# Altium schematic sheet p08_Camera.SchDoc
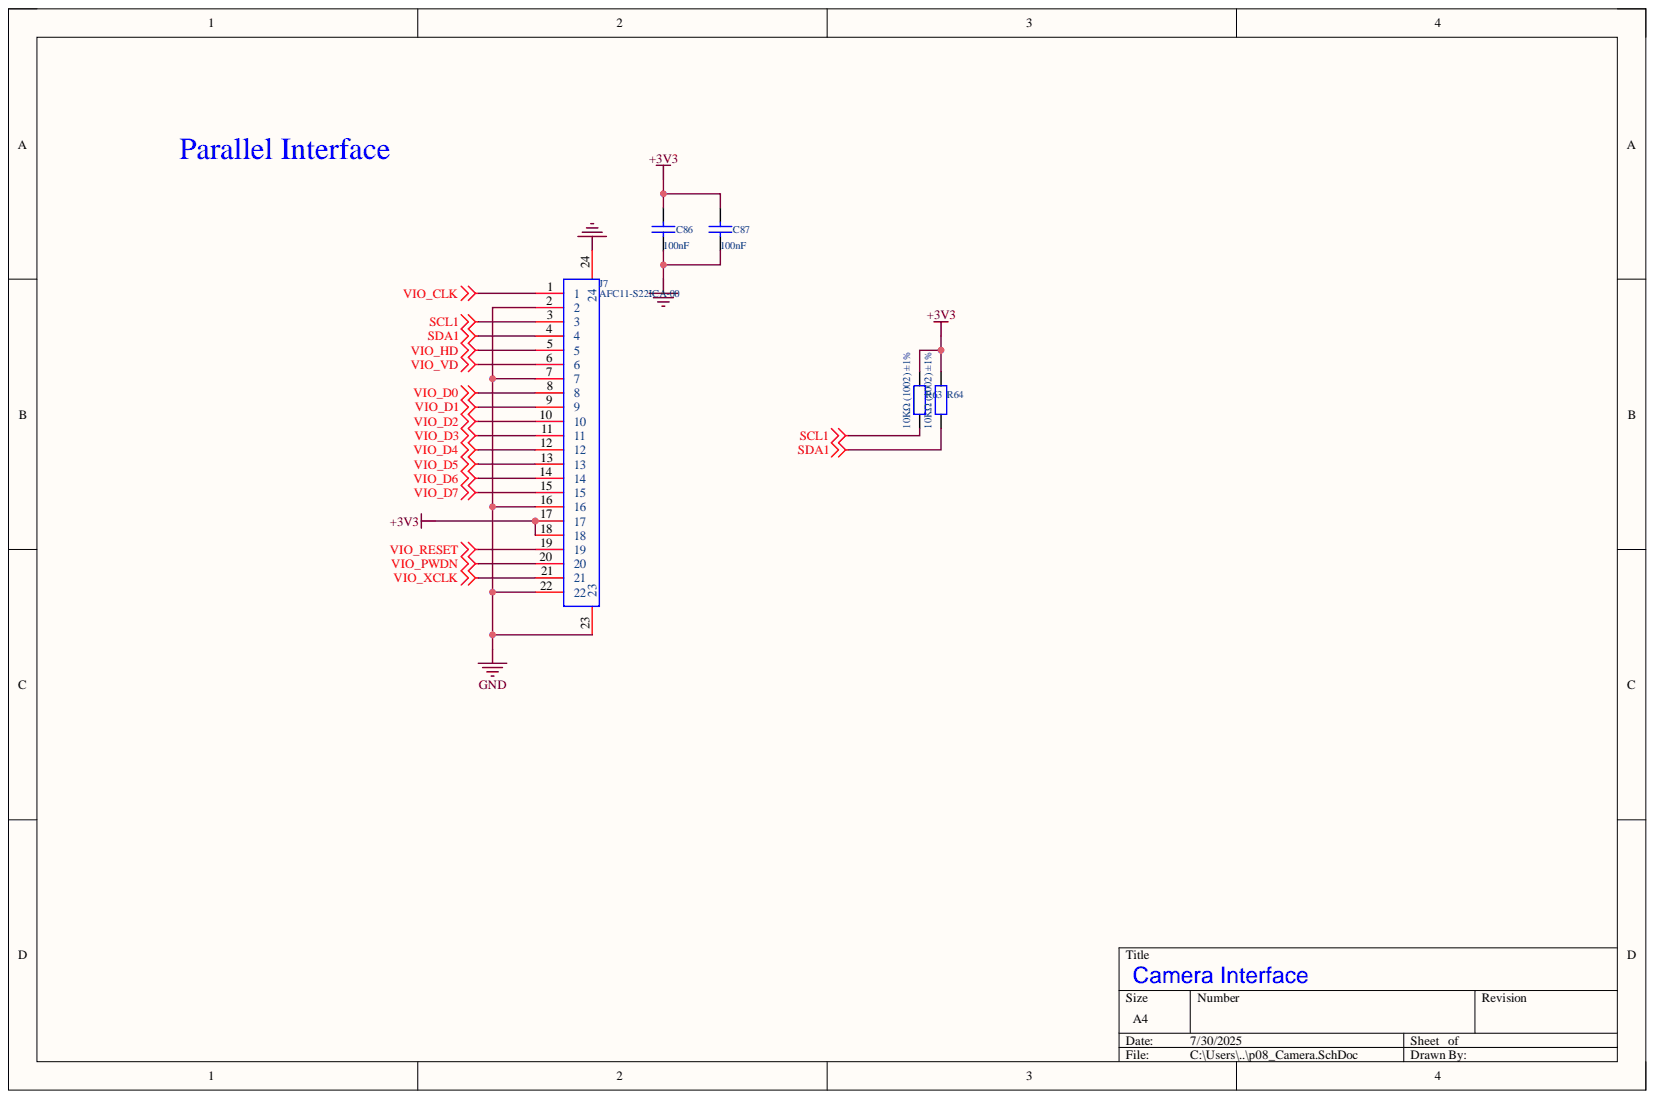
[diagram p0: p08_Camera.SchDoc]

## Parts
C86  Capacitance: 100nF  [C0402]  (hidden comment: 100 nf (0.1uF) 16V)
C87  Capacitance: 100nF  [C0402]  (hidden comment: 100 nf (0.1uF) 16V)
J7  AFC11-S22ICA-00  [FPC-SMD_22P-P1.00_AFC11-S22ICC-00]
R63  10KΩ (1002) ±1%  [R0402]
R64  10KΩ (1002) ±1%  [R0402]

## Nets
+3V3: C86.2 C87.2 J7.17 J7.18 R63.2 R64.2
GND: C86.1 C87.1 J7.2 J7.7 J7.16 J7.22 J7.23 J7.24
SCL1: J7.3 R63.1
SDA1: J7.4 R64.1
VIO_CLK: J7.1
VIO_D0: J7.8
VIO_D1: J7.9
VIO_D2: J7.10
VIO_D3: J7.11
VIO_D4: J7.12
VIO_D5: J7.13
VIO_D6: J7.14
VIO_D7: J7.15
VIO_HD: J7.5
VIO_PWDN: J7.20
VIO_RESET: J7.19
VIO_VD: J7.6
VIO_XCLK: J7.21

## Library data of the parts
C86 C87 = CAP-000030-01: Ceramic Chip Capacitor 0402 100 nf (0.1uF) 16V; Legacy Part Number: 151-10004022; Manufacturer: Yageo; Manufacturer Part Number 1: CC0402KRX7R8BB104; Dialectric: X7R; Notes: ANY EQUIVALENT; Tolerance: 10%; Component Kind: Standard; Library Name: Capacitors.IntLib; Manufacturer 1: Yageo; Manufacturer 2: Kemet; Manufacturer 3: AVX; Manufacturer Part Number 2: C0402C104K4RACTU; Manufacturer Part Number 3: 0402YC104KAT2A; Mfg: Yageo; Mfg P/N: CC0402KRX7R8BB104; Mfg Part Number: CC0402KRX7R8BB104; Package: 0402; PN: CC0402KRX7R8BB104; Supplier 1: Arrow Electronics; Supplier 2: Digi-Key; Supplier 3: Newark; Supplier 4: Mouser; Supplier Part Number 1: CC0402KRX7R8BB104; Supplier Part Number 2: 311-2077-1-ND; Supplier Part Number 3: 40AJ6370; Supplier Part Number 4: 603-CC402KRX7R8BB104; Voltage: 16V
J7 = AFC11-S22ICA-00: FPC，立贴，P=0.5mm; Manufacturer: JS(钜硕电子); Add into BOM: yes; Locking Feature: 抽屉式; Number of Contacts: 22P; Pitch: 0.5mm; Component Kind: Standard; Contact Type: 单侧触点/垂直; Convert to PCB: yes; Datasheet: https://atta.szlcsc.com/upload/public/pdf/source/20191211/C466537_F6F2472ADACFFA77E875AFE9DAB8E0AD.pdf; Device: AFC11-S22ICA-00; Height Above Board: 5.65mm; JLCPCB Part Class: Extended Part; LCSC Part Name: 间距:0.5mm P数:22P 抽屉式 单侧触点/垂直; Library Name: ra8p1_v0.1.SCHLIB; Manufacturer Part: AFC11-S22ICA-00; Mounting Type: 立贴; PCB3D: FPC-SMD_22P-P1.00_AFC11-S22ICC-00; Supplier: LCSC; Supplier Footprint: SMD,P=0.5mm; Supplier Part: C5248019; Symbol: AFC11-S22ICA-00
R63 R64 = 0402 10KΩ (1002) 1%: 贴片电阻，10K; Component Kind: Standard; ComponentLink1Description: 供应商链接; ComponentLink1URL: http://www.szlcsc.com/product/details_26487.html; Library Name: RES.SchLib; Package: 0402; Supplier: LC; SuppliersPartNumber: C25744
